# Altium schematic sheet analog_CH2.SchDoc
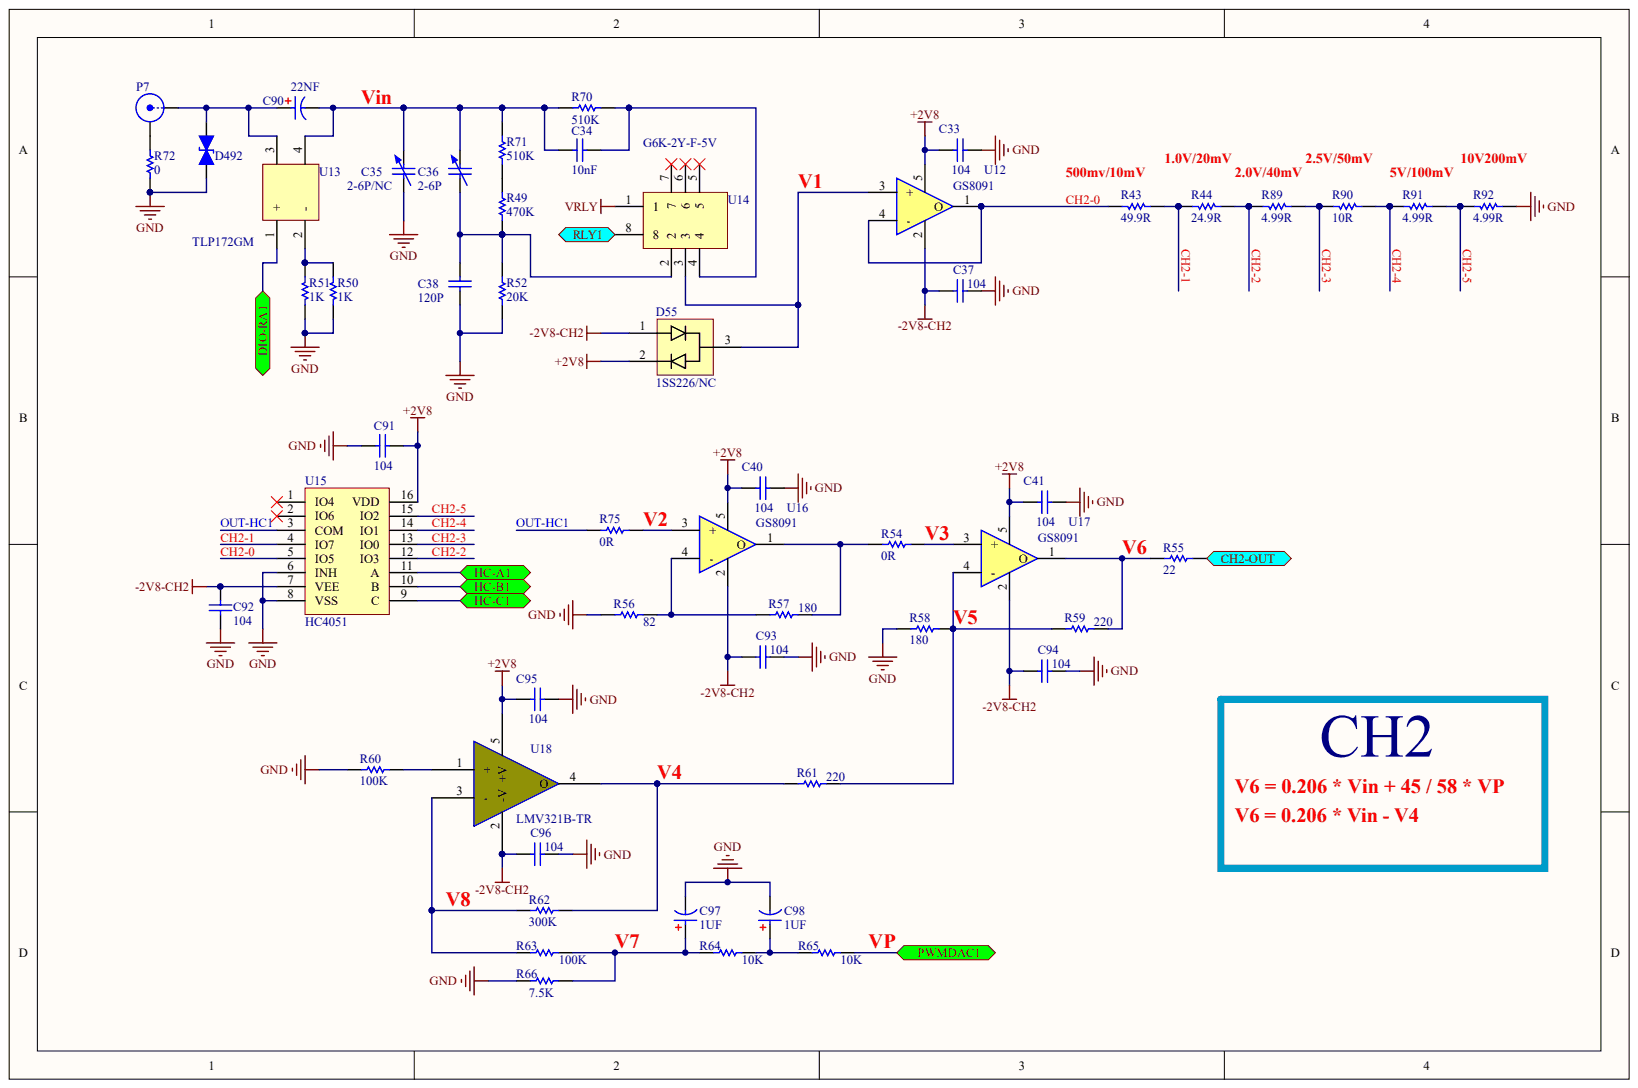
[diagram p0: analog_CH2.SchDoc]

## Parts
C33  C104  (value not drawn)
C34  10nF
C35  value 2-6P/NC  (hidden comment: V_CAP/NC)
C36  value 2-6P  (hidden comment: V_CAP)
C37  C104  (value not drawn)
C38  120P
C40  C104  (value not drawn)
C41  C104  (value not drawn)
C90  22NF
C91  C104  (value not drawn)
C92  C104  (value not drawn)
C93  C104  (value not drawn)
C94  C104  (value not drawn)
C95  C104  (value not drawn)
C96  C104  (value not drawn)
C97  1UF
C98  1UF
D55  1SS226/NC
D492  ESD_0402  (value not drawn)
P7  MCX  (value not drawn)
R43  49.9R
R44  24.9R
R49  470K
R50  1K
R51  1K
R52  20K
R54  0R
R55  22
R56  82
R57  180
R58  180
R59  220
R60  100K
R61  220
R62  300K
R63  100K
R64  10K
R65  10K
R66  7.5K
R70  510K
R71  510K
R72  0
R75  0R
R89  4.99R
R90  10R
R91  4.99R
R92  4.99R
U12  GS8091
U13  TLP172GM
U14  G6K-2Y-F-5V
U15  HC4051
U16  GS8091
U17  GS8091
U18  LMV321B-TR

## Ports
CH2-OUT, DIO-RA1, HC-A1, HC-B1, HC-C1, PWMDAC1, RLY1

## Nets
+2V8: C33.1 C40.1 C41.1 C91.2 C95.1 D55.2 U12.5 U15.16(VDD) U16.5 U17.5 U18.5(+V)
-2V8-CH2: C37.1 C92.1 C93.1 C94.1 C96.1 D55.1 U12.2 U15.7(VEE) U16.2 U17.2 U18.2(-V)
GND: C33.2 C35.1 C37.2 C38.2 C40.2 C41.2 C91.1 C92.2 C93.2 C94.2 C95.2 C96.2 C97.2 C98.2 D492.1 R50.1 R51.1 R52.2 R56.1 R58.1 R60.1 R66.1 R72.2 R92.1 U15.6(INH) U15.8(VSS)
VRLY: U14.1
CH2-0: R43.2 U12.1(O) U12.4(-) U15.5(IO5)
CH2-1: R43.1 R44.2 U15.4(IO7)
CH2-2: R44.1 R89.2 U15.12(IO3)
CH2-3: R89.1 R90.2 U15.13(IO0)
CH2-4: R90.1 R91.2 U15.14(IO1)
CH2-5: R91.1 R92.2 U15.15(IO2)
CH2-OUT: R55.2 ; port CH2-OUT
DIO-RA1: U13.1(+) ; port DIO-RA1
HC-A1: U15.11(A) ; port HC-A1
HC-B1: U15.10(B) ; port HC-B1
HC-C1: U15.9(C) ; port HC-C1
OUT-HC1: R75.1 U15.3(COM)
PWMDAC1: R65.2 ; port PWMDAC1
RLY1: U14.8 ; port RLY1
NetC34_1: C34.1 C35.2 C36.2 C90.2 R70.1 R71.1 U13.4
NetC34_2: C34.2 R70.2 U14.4
NetC36_1: C36.1 C38.1 R49.2 R52.1 U14.2
NetC90_1: C90.1 D492.2 P7.1 U13.3
NetC97_1: C97.1 R63.2 R64.1 R66.2
NetC98_1: C98.1 R64.2 R65.1
NetD55_3: D55.3 U12.3(+) U14.3
NetP7_2: P7.2 R72.1
NetR49_1: R49.1 R71.2
NetR50_2: R50.2 R51.2 U13.2(-)
NetR54_1: R54.1 R57.2 U16.1(O)
NetR54_2: R54.2 U17.3(+)
NetR55_1: R55.1 R59.2 U17.1(O)
NetR56_2: R56.2 R57.1 U16.4(-)
NetR58_2: R58.2 R59.1 R61.2 U17.4(-)
NetR60_2: R60.2 U18.1(+)
NetR61_1: R61.1 R62.2 U18.4(O)
NetR62_1: R62.1 R63.1 U18.3(-)
NetR75_2: R75.2 U16.3(+)

Not connected: U14 5 6 7; U15 1(IO4) 2(IO6)

## Library data of the parts
C33-C38 C40 C41 C90-C98 D55 D492 P7 R43 R44 R49-R52 R54-R66 R70-R72 R75 R89-R92 U12-U18 = (no symbol name)
  C33 C37 C40 C41 C91-C96: : 104
  C34: : 10nF
  C35 C36: Published: 24-Mar-1999; LatestRevisionDate: 29-May-2009; LatestRevisionNote: IPC-7351 Footprint Added.; PackageReference: C1210; PackageDescription: Chip Capacitor 1210 Case Size; Code_JEITA: 1210; Code_IPC: CAPC3225
  C38: : 120P
  C90: : 22NF
  C97 C98: : 1UF
  P7: LatestRevisionDate: 17-Jul-2002; LatestRevisionNote: Re-released for DXP Platform.
  R43: : 49.9R
  R44: : 24.9R
  R49: : 470K
  R50 R51: : 1K
  R52: : 20K
  R54 R75: : 0R
  R55: : 22
  R56: : 82
  R57 R58: : 180
  R59 R61: : 220
  R60 R63: : 100K
  R62: : 300K
  R64 R65: : 10K
  R66: : 7.5K
  R70 R71: : 510K
  R72: : 0
  R89 R91 R92: : 4.99R
  R90: : 10R
  C35 C36 P7: Publisher: Altium Limited
